# Altium schematic sheet General Overview.SchDoc("General Overview")
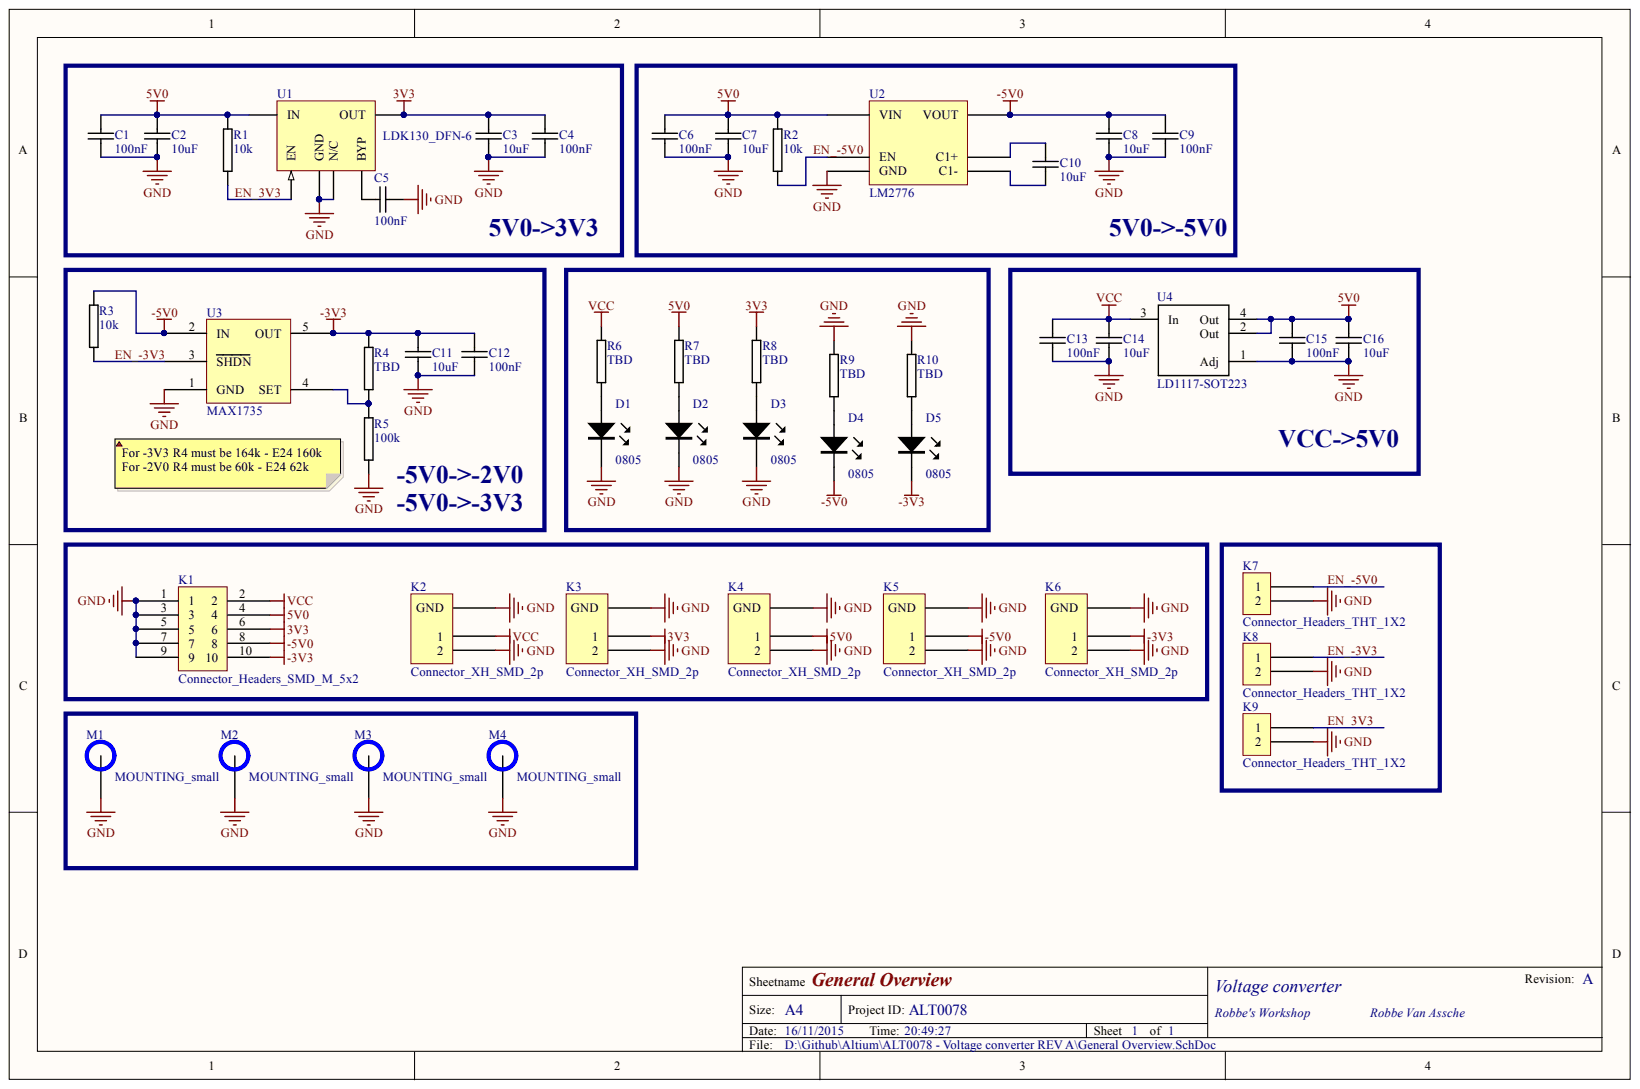
[diagram p0: General Overview.SchDoc]

## Parts
C1  100nF  [0603C-GENERIC]
C2  10uF  [1210C-GENERIC]
C3  10uF  [1210C-GENERIC]
C4  100nF  [0603C-GENERIC]
C5  100nF  [0603C-GENERIC]
C6  100nF  [0603C-GENERIC]
C7  10uF  [1210C-GENERIC]
C8  10uF  [1210C-GENERIC]
C9  100nF  [0603C-GENERIC]
C10  10uF  [1210C-GENERIC]
C11  10uF  [1210C-GENERIC]
C12  100nF  Reel: C12  [0603C-GENERIC]
C13  100nF  [0603C-GENERIC]
C14  10uF  [1210C-GENERIC]
C15  100nF  [0603C-GENERIC]
C16  10uF  [1210C-GENERIC]
D1  0805  [0805LED]
D2  0805  [0805LED]
D3  0805  [0805LED]
D4  0805  [0805LED]
D5  0805  [0805LED]
K1  Connector_Headers_SMD_M_5x2  [Header_Male_2.54 5x2_COMBO]
K2  Connector_XH_SMD_2p  [2.54_2p_THT+SMD]
K3  Connector_XH_SMD_2p  [2.54_2p_THT+SMD]
K4  Connector_XH_SMD_2p  [2.54_2p_THT+SMD]
K5  Connector_XH_SMD_2p  [2.54_2p_THT+SMD]
K6  Connector_XH_SMD_2p  [2.54_2p_THT+SMD]
K7  Connector_Headers_THT_1X2  [HEADER-1X2]
K8  Connector_Headers_THT_1X2  [HEADER-1X2]
K9  Connector_Headers_THT_1X2  [HEADER-1X2]
M1  MOUNTING_small  [MOUNTING M3 small]
M2  MOUNTING_small  [MOUNTING M3 small]
M3  MOUNTING_small  [MOUNTING M3 small]
M4  MOUNTING_small  [MOUNTING M3 small]
R1  10k  [R0402]
R2  10k  [R0402]
R3  10k  [R0402]
R4  TBD  [R0402]
R5  100k  [R0402]
R6  TBD  [R0402]
R7  TBD  [R0402]
R8  TBD  [R0402]
R9  TBD  [R0402]
R10  TBD  [R0402]
U1  LDK130_DFN-6  [DFN65P130X50-6L]
U2  LM2776  [SOT23-6_L]
U3  MAX1735  [SOT23-5]
U4  LD1117-SOT223  [SOT223]

## Nets
3V3: C3.1 C4.1 K1.6 K3.1 R8.1 U1.1(OUT)
5V0: C1.2 C2.1 C6.2 C7.1 C15.2 C16.1 K1.4 K4.1 R1.1 R2.1 R7.1 U1.6(IN) U2.3(VIN) U4.2(Out) U4.4(Out)
-3V3: C11.1 C12.1 D5.K K1.10 K6.1 R4.1 U3.5(OUT)
-5V0: C8.1 C9.1 D4.K K1.8 K5.1 R3.1 U2.1(VOUT) U3.2(IN)
GND: C1.1 C2.2 C3.2 C4.2 C5.2 C6.1 C7.2 C8.2 C9.2 C11.2 C12.2 C13.1 C14.2 C15.1 C16.2 D1.K D2.K D3.K K1.1 K1.3 K1.5 K1.7 K1.9 K2.0 K2.2 K3.0 K3.2 K4.0 K4.2 K5.0 K5.2 K6.0 K6.2 K7.2 K8.2 K9.2 M1.1 M2.1 M3.1 M4.1 R5.2 R9.1 R10.1 U1.2(GND) U1.5(N/C) U2.2(GND) U3.1(GND) U4.1(Adj)
VCC: C13.2 C14.1 K1.2 K2.1 R6.1 U4.3(In)
EN_3V3: K9.1 R1.2 U1.4(EN)
EN_-3V3: K8.1 R3.2 U3.3(SHDN)
EN_-5V0: K7.1 R2.2 U2.4(EN)
NetC5_1: C5.1 U1.3(BYP)
NetC10_1: C10.1 U2.5(C1+)
NetC10_2: C10.2 U2.6(C1-)
NetD1_A: D1.A R6.2
NetD2_A: D2.A R7.2
NetD3_A: D3.A R8.2
NetD4_A: D4.A R9.2
NetD5_A: D5.A R10.2
NetR4_2: R4.2 R5.1 U3.4(SET)

## Library data of the parts
C1 C4-C6 C9 C13 C15 = Passives_Capacitors_0603_100NF-25V-X7R-TDK: CAPACITOR 0603 CERAMIC; Manufacturer: TDK Corporation; Manufacturer Part Number: C1608X7R1E104K; Reel: C12; Component Kind: Standard; Supplier 1: Digi-Key; Supplier Part Number 1: 445-1316-2-ND; Library Name: RVA_Passives_Capacitors_0603.SchLib
C2 C3 C7 C8 C10 C11 C14 C16 = Passives_Capacitors_1210_1210C-TBD: CAPACITOR 1210 CERAMIC VALUE TO BE DETERMINED; Component Kind: Standard; Library Name: RVA_Passives_Capacitors_1210.SchLib
C12 = Passives_Capacitors_0603_100NF-25V-X7R-TDK: CAPACITOR 0603 CERAMIC; Manufacturer: TDK Corporation; Manufacturer Part Number: C1608X7R1E104K; Component Kind: Standard; Supplier 1: Digi-Key; Supplier Part Number 1: 445-1316-2-ND; Library Name: RVA_Passives_Capacitors_0603.SchLib
D1-D5 = LED_SMD_0805: Component Kind: Standard; Library Name: RVA_LED_SMD_0805.SchLib
K1 = Connector_Headers_SMD_M_5x2: SMD Header 5x2; Component Kind: Standard; Library Name: RVA_Connector_Headers_SMD.SchLib
K7-K9 = Connector_Headers_THT_1X2: REEL: TH; Component Kind: Standard; Supplier 1: Digi-Key; Supplier Part Number 1: S1011E-36-ND; Library Name: RVA_Connector_Headers_THT.SchLib
M1-M4 = MOUNTING_small: MOUNTING HOLE - NON INSTALLABLE; Component Kind: Standard; Library Name: RVA_Mechanical_THT.SchLib
R1-R10 = Passives_Resistors_0402_TBD: RESISTOR 0402 VALUE TO BE DETERMINED; Component Kind: Standard; Library Name: RVA_Passives_Resistors_0402.SchLib
U1 = LDK130_DFN-6: 300 mA low quiescent current very low noise LDO; Component Kind: Standard; Library Name: RVA_PSU_LDO_SMD.SchLib
U2 = LM2776_SOT23-6: LM2776 CMOS charge-pump voltage converter; Component Kind: Standard; Library Name: RVA_PSU_CAP INV_SMD.SchLib
K2-K6 = Connector_XH_SMD_2p: Component Kind: Standard; Library Name: RVA_Connector_XH_SMD.SchLib
U3 = MAX1735: Component Kind: Standard; Library Name: RVA_PSU_LDO_SMD.SchLib
U4 = LD1117-SOT223: Component Kind: Standard; Library Name: RVA_PSU_LDO_SMD.SchLib
